# Altium schematic sheet LinearAmp.SchDoc
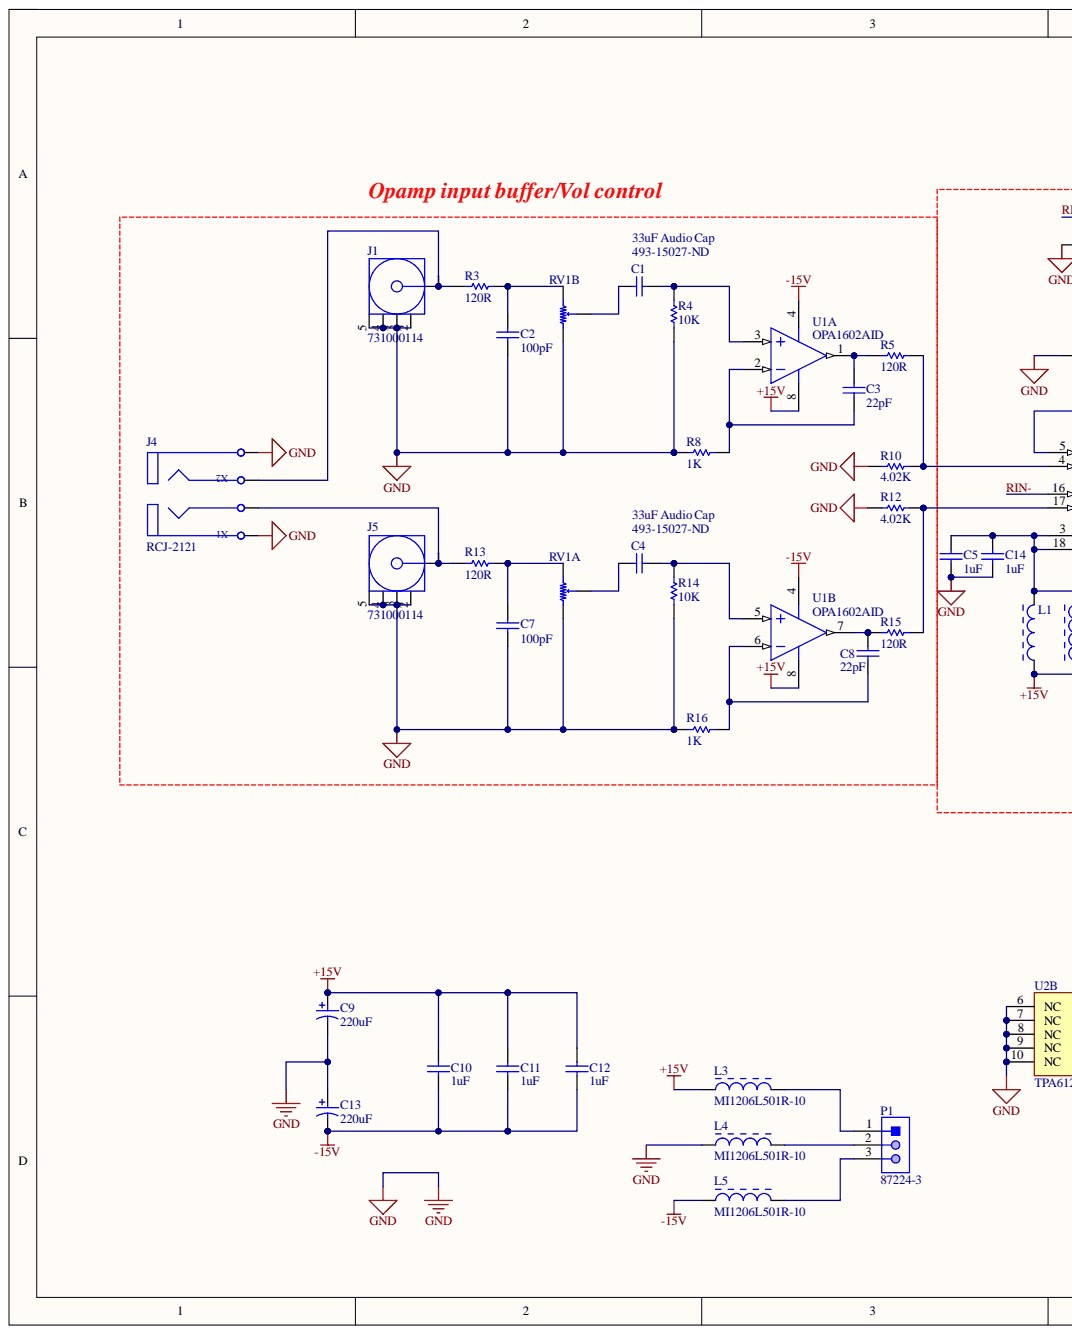
[diagram p0: LinearAmp.SchDoc, left]
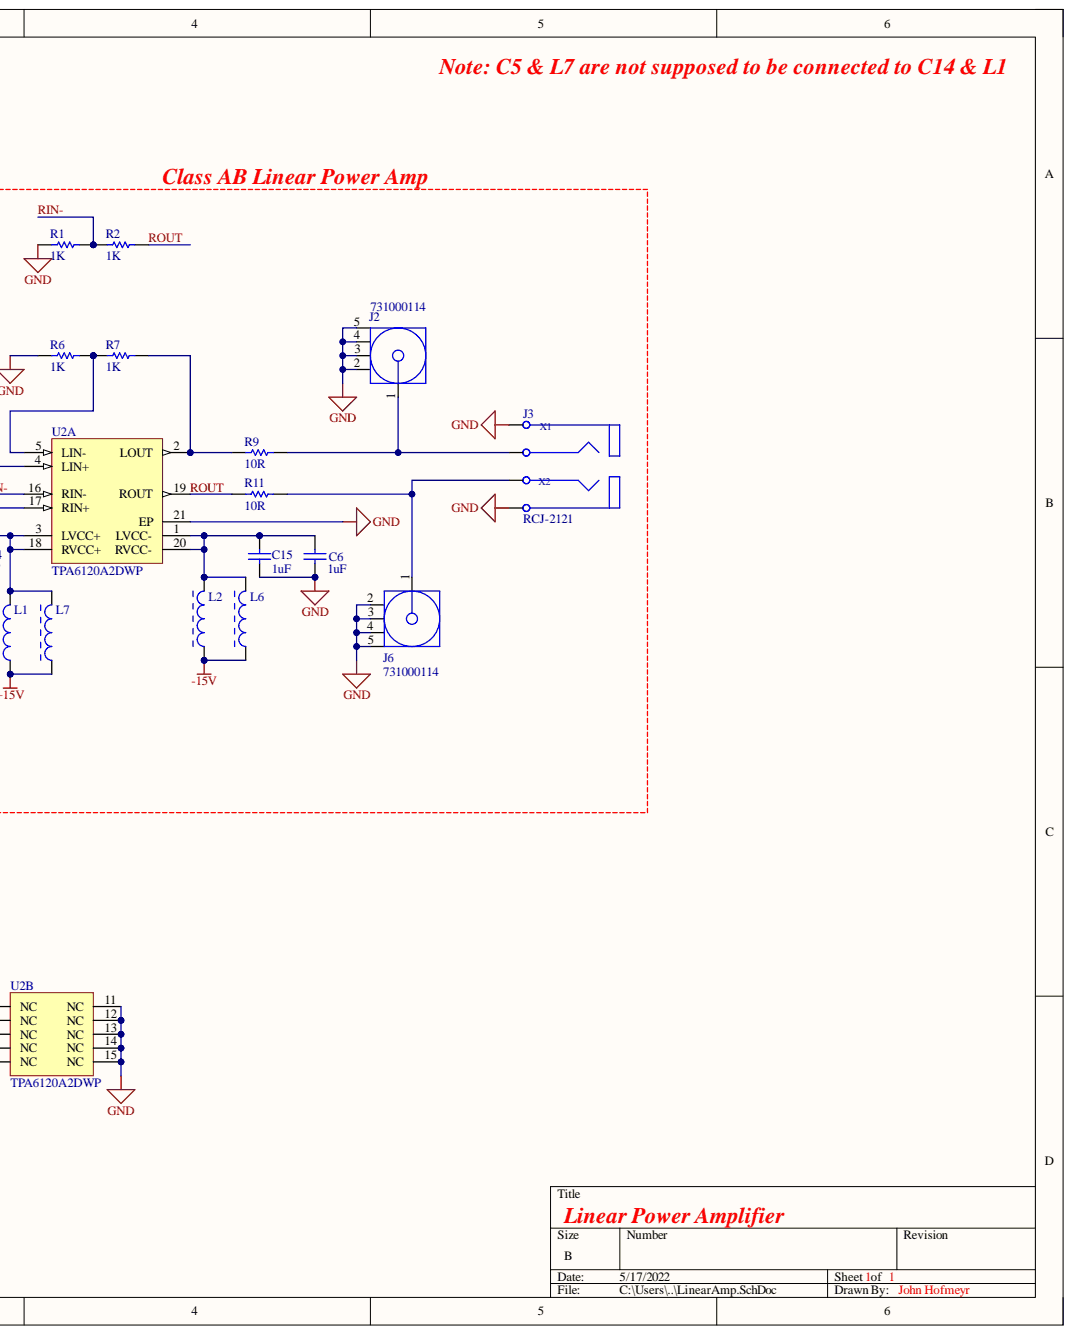
[diagram p1: LinearAmp.SchDoc, right]

## Parts
C1  33uF Audio Cap  Supplier Part Number 1: 493-15027-ND  [C-8.3]
C2  100pF  [CAPC2013X94X50LL20T25]
C3  22pF  [CAPC2013X94X50LL20T25]
C4  33uF Audio Cap  Supplier Part Number 1: 493-15027-ND  [C-8.3]
C5  1uF  [CAPC2013X140X50LL20T25]
C6  1uF  [CAPC2013X140X50LL20T25]
C7  100pF  [CAPC2013X94X50LL20T25]
C8  22pF  [CAPC2013X94X50LL20T25]
C9  220uF  [CAPPR65-500-1250X1000X1350]
C10  1uF  [CAPC2013X140X50LL20T25]
C11  1uF  [CAPC2013X140X50LL20T25]
C12  1uF  [CAPC2013X140X50LL20T25]
C13  220uF  [CAPPR65-500-1250X1000X1350]
C14  1uF  [CAPC2013X140X50LL20T25]
C15  1uF  [CAPC2013X140X50LL20T25]
J1  731000114  [MOLX-731000114_V]
J2  731000114  [MOLX-731000114_V]
J3  RCJ-2121  [RCJ-2121]
J4  RCJ-2121  [RCJ-2121]
J5  731000114  [MOLX-731000114_V]
J6  731000114  [MOLX-731000114_V]
L1  MI1206L501R-10  [LIRD-HI1206T500R-10_V]
L2  MI1206L501R-10  [LIRD-HI1206T500R-10_V]
L3  MI1206L501R-10  [LIRD-HI1206T500R-10_V]
L4  MI1206L501R-10  [LIRD-HI1206T500R-10_V]
L5  MI1206L501R-10  [LIRD-HI1206T500R-10_V]
L6  MI1206L501R-10  [LIRD-HI1206T500R-10_V]
L7  MI1206L501R-10  [LIRD-HI1206T500R-10_V]
P1  87224-3  [TECO-87224-3_V]
R1  1K  [RESC2013X50X40LL20T20]
R2  1K  [RESC2013X50X40LL20T20]
R3  120R  [RESC2013X50X40LL20T20]
R4  10K  [RESC2013X50X40LL20T20]
R5  120R  [RESC2013X50X40LL20T20]
R6  1K  [RESC2013X50X40LL20T20]
R7  1K  [RESC2013X50X40LL20T20]
R8  1K  [RESC2013X50X40LL20T20]
R9  10R  [RESC3225X70X50ML20T20]
R10  4.02K  [RESC2013X50X40ML20T20]
R11  10R  [RESC3225X70X50ML20T20]
R12  4.02K  [RESC2013X50X40ML20T20]
R13  120R  [RESC2013X50X40LL20T20]
R14  10K  [RESC2013X50X40LL20T20]
R15  120R  [RESC2013X50X40LL20T20]
R16  1K  [RESC2013X50X40LL20T20]
RV1  Dual 10K Log Potentiometer  [Potentiometer]  (value not drawn)  (parts A,B)
U1  OPA1602AID  [D0008A_M]  (parts A,B)
U2  TPA6120A2DWP  [DWP0020B_L]  (parts A,B)

## Nets
+15V: C9.1 C10.2 C11.2 C12.2 L1.1 L3.1 L7.1 U1.8
-15V: C10.1 C11.1 C12.1 C13.2 L2.1 L5.1 L6.1 U1.4
GND: C2.2 C5.1 C6.1 C7.2 C9.2 C13.1 C14.1 C15.1 J1.2 J1.3 J1.4 J1.5 J2.2 J2.3 J2.4 J2.5 J3.1(X1) J3.3 J4.1(X1) J4.3 J5.2 J5.3 J5.4 J5.5 J6.2 J6.3 J6.4 J6.5 L4.1 R1.1 R4.1 R6.2 R8.1 R10.2 R12.2 R14.1 R16.1 RV1.1 RV1.4 U2.6(NC) U2.7(NC) U2.8(NC) U2.9(NC) U2.10(NC) U2.11(NC) U2.12(NC) U2.13(NC) U2.14(NC) U2.15(NC) U2.21(EP)
RIN-: R1.2 R2.1 U2.16(RIN-)
ROUT: R2.2 R11.1 U2.19(ROUT)
NetC1_1: C1.1 R4.2 U1.3
NetC1_2: C1.2 RV1.5
NetC2_1: C2.1 R3.2 RV1.6
NetC3_1: C3.1 R8.2 U1.2
NetC3_2: C3.2 R5.1 U1.1
NetC4_1: C4.1 R14.2 U1.5
NetC4_2: C4.2 RV1.2
NetC5_2: C5.2 C14.2 L1.2 L7.2 U2.3(LVCC+) U2.18(RVCC+)
NetC6_2: C6.2 C15.2 L2.2 L6.2 U2.1(LVCC-) U2.20(RVCC-)
NetC7_1: C7.1 R13.2 RV1.3
NetC8_1: C8.1 R16.2 U1.6
NetC8_2: C8.2 R15.1 U1.7
NetJ1_1: J1.1 J4.4(X2) R3.1
NetJ2_1: J2.1 J3.2 R9.2
NetJ3_4: J3.4(X2) J6.1 R11.2
NetJ4_2: J4.2 J5.1 R13.1
NetL3_2: L3.2 P1.1
NetL4_2: L4.2 P1.2
NetL5_2: L5.2 P1.3
NetR5_2: R5.2 R10.1 U2.4(LIN+)
NetR6_1: R6.1 R7.1 U2.5(LIN-)
NetR7_2: R7.2 R9.1 U2.2(LOUT)
NetR12_1: R12.1 R15.2 U2.17(RIN+)

## Library data of the parts
C1 C4 = UES1E330MPM: Component Kind: Standard; Library Name: Capacitor.SchLib; Supplier 1: Digi-Key
C2 C7 = CMP-2007-04121-1: Manufacturer: AVX Interconnect / Elco; Part Number: 08051A101JAT2A; Component Kind: Standard; Library Name: Altium Content Vault
C3 C8 = CMP-2007-04137-1: Manufacturer: AVX Interconnect / Elco; Part Number: 08051A220KAT2A; Component Kind: Standard; Library Name: Altium Content Vault
C5 C6 C10-C12 C14 C15 = CMP-1036-04738-2: Manufacturer: AVX Interconnect / Elco; Part Number: 0805ZC105KAT2A; Component Kind: Standard; Library Name: Altium Content Vault
C9 C13 = CMP-1665-00043-1: Aluminum Electrolytic Capacitor, 220 uF, +/- 20%, 50 V, -40 to 85 degC, 2-Pin THD, RoHS, Bulk; Case\Package: SOIC; Channels: 2; Data Rate: 120 kbps; Interface: RS-232; Max Supply Voltage: 5.5 V; Min Supply Voltage: 4.5 V; Nominal Supply Current: 10 mA; Nominal Supply Voltage: 5 V; Propagation Delay: 10 us; Component Kind: Standard; ComponentLink1Description: Manufacturer URL; ComponentLink1URL: http://www.panasonic.com/; ComponentLink2Description: Datasheet; ComponentLink2URL: http://industrial.panasonic.com/cdbs/www-data/pdf/RDF0000/DMF0000COL68.pdf; DatasheetVersion: Mar-16; Height: 1.5 mm; Length: 10 mm; Library Name: Altium Content Vault; Max Operating Temperature: 70 degC; Min Operating Temperature: 0 degC; Mount: Surface Mount; Package Quantity: 50; PackageDescription: 2-Pin Radial Lead, Dia 10 mm, Body Length 12.5 mm; PackageReference: CAPPR65-500-1250X1000X1350; Packaging: Rail/Tube; Pins: 16; Radiation Hardening: No; REACH SVHC: No SVHC; RoHSCompliant: true; Width: 4 mm
J1 J2 J5 J6 = CMP-2000-05487-1: SMA Right-Angle PCB Jack Receptacle, Brass Body, Gold Plated, 50 Ohm, 5-Pin THD, RoHS, Tray; Manufacturer: Molex; Component Kind: Standard; Datasheet URL: http://www.molex.com/webdocs/datasheets/pdf/en-us/0731000114_RF_COAX_CONNECTORS.pdf; Datasheet Version: 09/2016; Library Name: Altium Content Vault; Manufacturer URL: http://www.molex.com/; Mounting Technology: ThroughHole; Package Description: 5-Pin Through Hole Device, Body 14.8 x 6.35 mm, Pitch 2.54 mm; Package Reference: 731000114; Package URL: http://www.molex.com/pdm_docs/sd/731000114_sd.pdf
J3 J4 = RCJ-2121: MF: {'manufacturer': u'CUI Devices'}; Availability: Unavailable; Component Kind: Standard; Library Name: SnapEDA_Library.IntLib; MP: RCJ-2121; Package: None; Price: None
L1-L7 = CMP-2000-06703-1: Chip Bead, 100 MHz, 2 A, -40 to 125 degC, 2-Pin SMD (1206), RoHS, Tape and Reel; Manufacturer: Laird; Catalog Drawings: (HI,HZ,LF,LI,MI)1206Series_1.30mmMax.Height; Current Rating (Max): 2A; DC Resistance (DCR) (Max): 60mOhm; Filter Type: PowerLine; Impedance @ Frequency: 500Ohm@100MHz; Kits: 240-2227-ND-KITEMIFERRITEBEADCHIPSMD; Number of Lines: 1; Online Catalog: MISeries; Other Names: 240-2407-1; Category: Filters; Component Kind: Standard; Datasheet URL: http://cdn.lairdtech.com/home/brandworld/files/MI1206L501R-10.pdf; Datasheet Version: Rev. E, 08/2013; Family: FerriteBeadsandChips; Height (Max): 0.051"(1.30mm); Library Name: Altium Content Vault; Manufacturer URL: https://www.lairdtech.com/; Mounting Technology: SurfaceMount; Mounting Type: SurfaceMount; Operating Temperature: -40°C~125°C; Package / Case: 1206(3216Metric); Package Description: 2-Pin Surface Mount Device, Body 3.2 x 1.6 mm; Package Reference: HI1206T500R-10; Packaging: CutTape(CT); Ratings: -; Series: MI; Size / Dimension: 0.126"Lx0.063"W(3.20mmx1.60mm); Standard Package: 1
P1 = CMP-2000-05697-1: Male Header, Pitch 2.54 mm, 1 x 3 Position, Height 10.744 mm, Tail Length 3.175 mm, -65 to 105 degC, RoHS, Bulk; Manufacturer: TE Connectivity; 3D Model: 87224-3.pdf; Catalog Drawings: SingleSquareStraightPost    SingleSquareStraightPostSide; Connector Type: Header,Unshrouded; Dynamic Catalog: AMPMODUModIISeries; Featured Product: AMPMODUConnectors; Mating Products: 147738-2-ND  -CONNRCPT3POSVERTSMDGOLD  147723-2-ND  -CONNRCPT3POSVERT.100GOLD  146763-5-ND  -CONNRCPT3POSSGLVERT.100T/H  A32904-ND  -CONNRECEPT3POS.100VERTGOLD  A26922-ND  -CONNHOUSING3POS.100LOCKING  A26415-ND  -CONNRECEPT3POS.100VERTAU  A26964-ND  -CONNHOUSING3POS.100SINGLE  A3012-ND  -CONNHOUSING3POS.100SINGLE; Number of Positions: 3; Number of Positions Loaded: All; Other Names: 87224-3-ND  A26545; Pitch: 0.100"(2.54mm); Category: Connectors,Interconnects; Color: Black; Component Kind: Standard; Contact Finish: Gold; Contact Finish Thickness: 15µin(0.38µm); Contact Mating Length: 0.318"(8.08mm); Contact Type: MalePin; Datasheet URL: http://www.te.com/commerce/DocumentDelivery/DDEController?Action=showdoc&DocId=Customer+Drawing%7F87224%7FL3%7Fpdf%7FEnglish%7FENG_CD_87224_L3.pdf%7F87224-3; Datasheet Version: Rev. L3, 08/2015; Family: RectangularConnectors-Headers,MalePins; Library Name: Altium Content Vault; Manufacturer URL: http://www.te.com/; Mounting Technology: ThroughHole; Mounting Type: ThroughHole; Number of Rows: 1; Package Description: Male Header, Pitch 2.54 mm, 1 x 3 Position, Height 10.744 mm, Tail Length 3.175 mm; Package Reference: 87224-3; Packaging: Bulk; RoHS Information: 87224-3StatementofCompliance; Series: AMPMODUModII; Standard Package: 520; Termination: Solder
R1 R2 R6-R8 R16 = CMP-2001-03210-1: Manufacturer: Susumu; Part Number: RR1220P-102-D; Component Kind: Standard; Library Name: Altium Content Vault
R3 R5 R13 R15 = CMP-2001-03236-1: Manufacturer: Susumu; Part Number: RR1220P-121-D; Component Kind: Standard; Library Name: Altium Content Vault
R4 R14 = CMP-2001-03215-1: Manufacturer: Susumu; Part Number: RR1220P-103-D; Component Kind: Standard; Library Name: Altium Content Vault
R9 R11 = CMP-2005-03848-1: Manufacturer: Panasonic; Part Number: ERJP14F10R0U; Component Kind: Standard; Library Name: Altium Content Vault
R10 R12 = CMP-2001-03410-1: Manufacturer: Susumu; Part Number: RR1220P-4021-D-M; Component Kind: Standard; Library Name: Altium Content Vault
RV1 = Dual Pot: Component Kind: Standard; Library Name: Potentiometer.SchLib; Supplier 1: Digi-Key; Supplier Part Number 1: PTD902-1025K-A103-ND
U1 = CMP-0271-00137-3: Sound Plus High-Performance, Bipolar-Input Audio Operational Amplifier, 4.5 to 36 V, -40 to 85 degC, 8-pin SOIC (D8), Green (RoHS & no Sb/Br); Manufacturer: Texas Instruments; CMRR(Min)(dB): 114; GBW(Typ)(MHz): 35; IIB(Max)(pA): 200000; Iq per channel(Max)(mA): 2.6; Number of Channels: 2; Packing: TUBE; Rating: Catalog; Slew Rate(Typ)(V/us): 20; Sub Family: Audio Operational Amplifier, High Output Current Amplifier (>=50mA), High Supply Voltage Amplifier (>=30V), Low Noise Amp (<=10nV/rtHz), Precision Amplifier; Total Supply Voltage(Max)(+5V is 5, +/-5V is 10): 36; Total Supply Voltage(Min)(+5V is 5, +/-5V is 10): 4.5; Vio (25C)(Max)(mV): 1; Code_JEDEC: MS-012; Component Kind: Standard; ComponentLink1Description: Manufacturer URL; ComponentLink1URL: http://www.ti.com/; ComponentLink2Description: Datasheet; ComponentLink2URL: http://www.ti.com/lit/ds/symlink/opa1602.pdf; ComponentLink3Description: Package Specification; ComponentLink3URL: http://www.ti.com/litv/pdf/msoi002j; DatasheetVersion: SBOS474B; Eco-Plan: Green (RoHS & no Sb/Br); Library Name: Altium Content Vault; Mounting Technology: Surface Mount; PackageDescription: 8-Pin Plastic Small Outline, Body 4.9 x 3.9 mm, Pitch 1.27 mm; PackageReference: D0008A; PackageVersion: 4040047-3/M, 06/2011; PartNumber: OPA1602AID
U2 = CMP-0993-00039-2: High Fidelity Stereo Headphone Amplifier, -40 to 85 degC, 20-pin SOP (DWP20), Green (RoHS & no Sb/Br); Manufacturer: Texas Instruments; Load(Min)(ohms): 16; PSRR(dB): 75; Special Features: Hi-Fi; Supply Max(Max)(Volt): 30; Supply Min(Min)(Volt): 10; Volume Control: Yes; Component Kind: Standard; ComponentLink1Description: Manufacturer URL; ComponentLink1URL: http://www.ti.com/; ComponentLink2Description: Datasheet; ComponentLink2URL: http://www.ti.com/general/docs/lit/getliterature.tsp?genericPartNumber=TPA6120A2&fileType=pdf; ComponentLink3Description: Package Specification; ComponentLink3URL: http://www.ti.com/litv/pdf/mpds032b; DatasheetVersion: SLOS431; Eco-Plan: Green (RoHS & no Sb/Br); Headphone Channels: Stereo; Library Name: Altium Content Vault; Mounting Technology: Surface Mount; PackageDescription: 20-Pin PowerPAD(TM) Plastic Small-Outline Package, Body 12.825 x 7.52 mm, Pitch 1.27 mm; PackageReference: DWP0020B; PackageVersion: 4147575/C, 02/2005; PartNumber: TPA6120A2DWP; SubFamily: Headphone Amplifier
